# Altium schematic sheet 16-ESP32S3.SchDoc(16-ESP32S3)
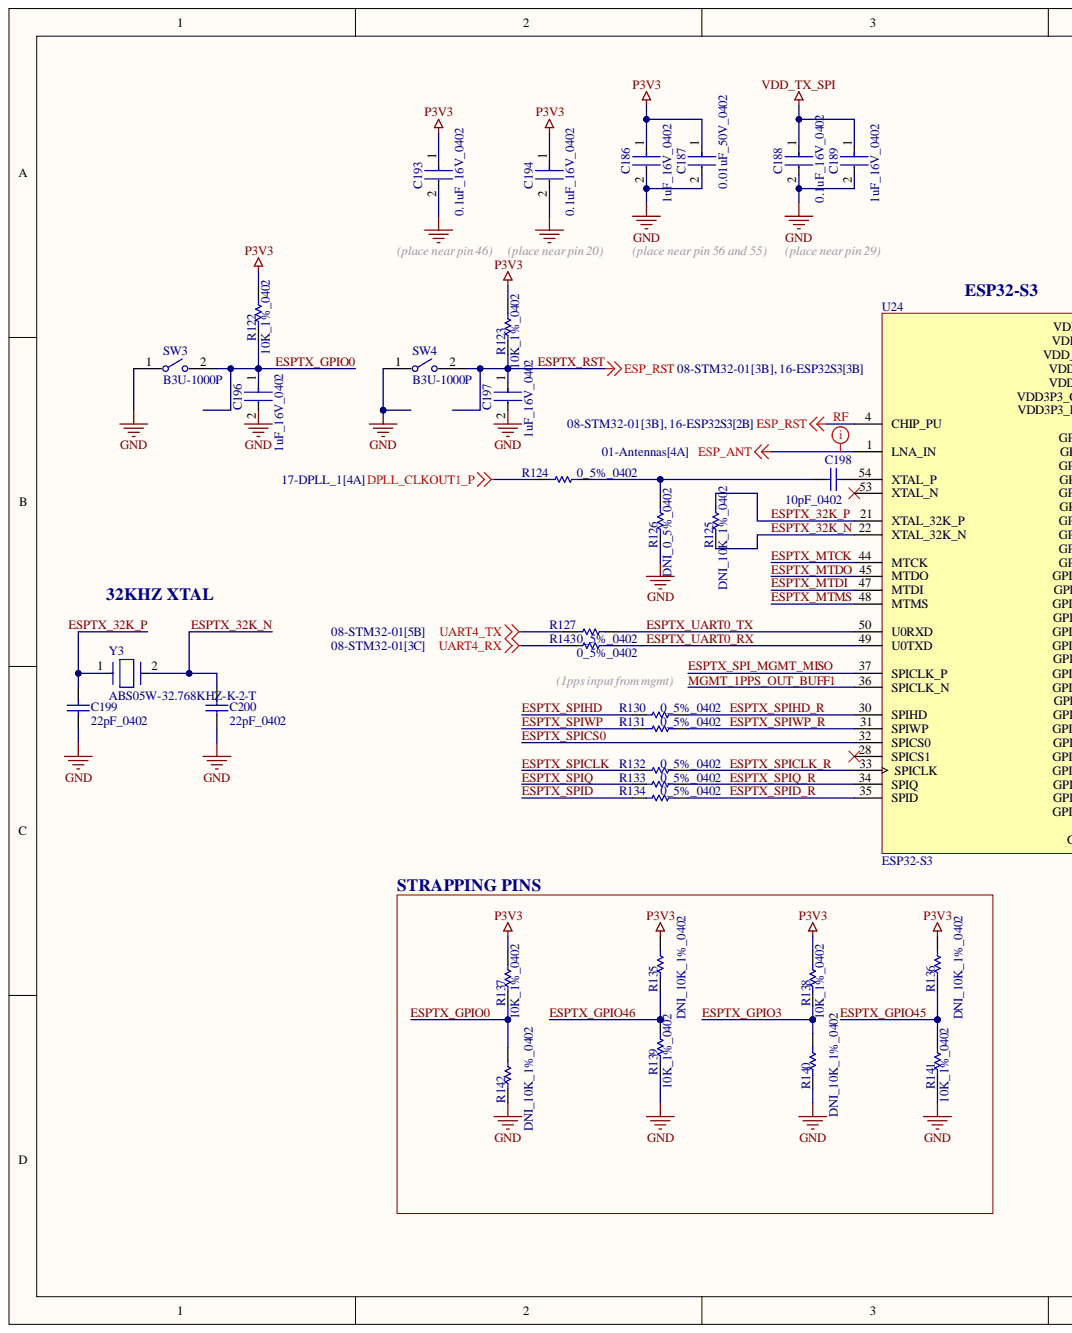
[diagram p0: 16-ESP32S3.SchDoc, left]
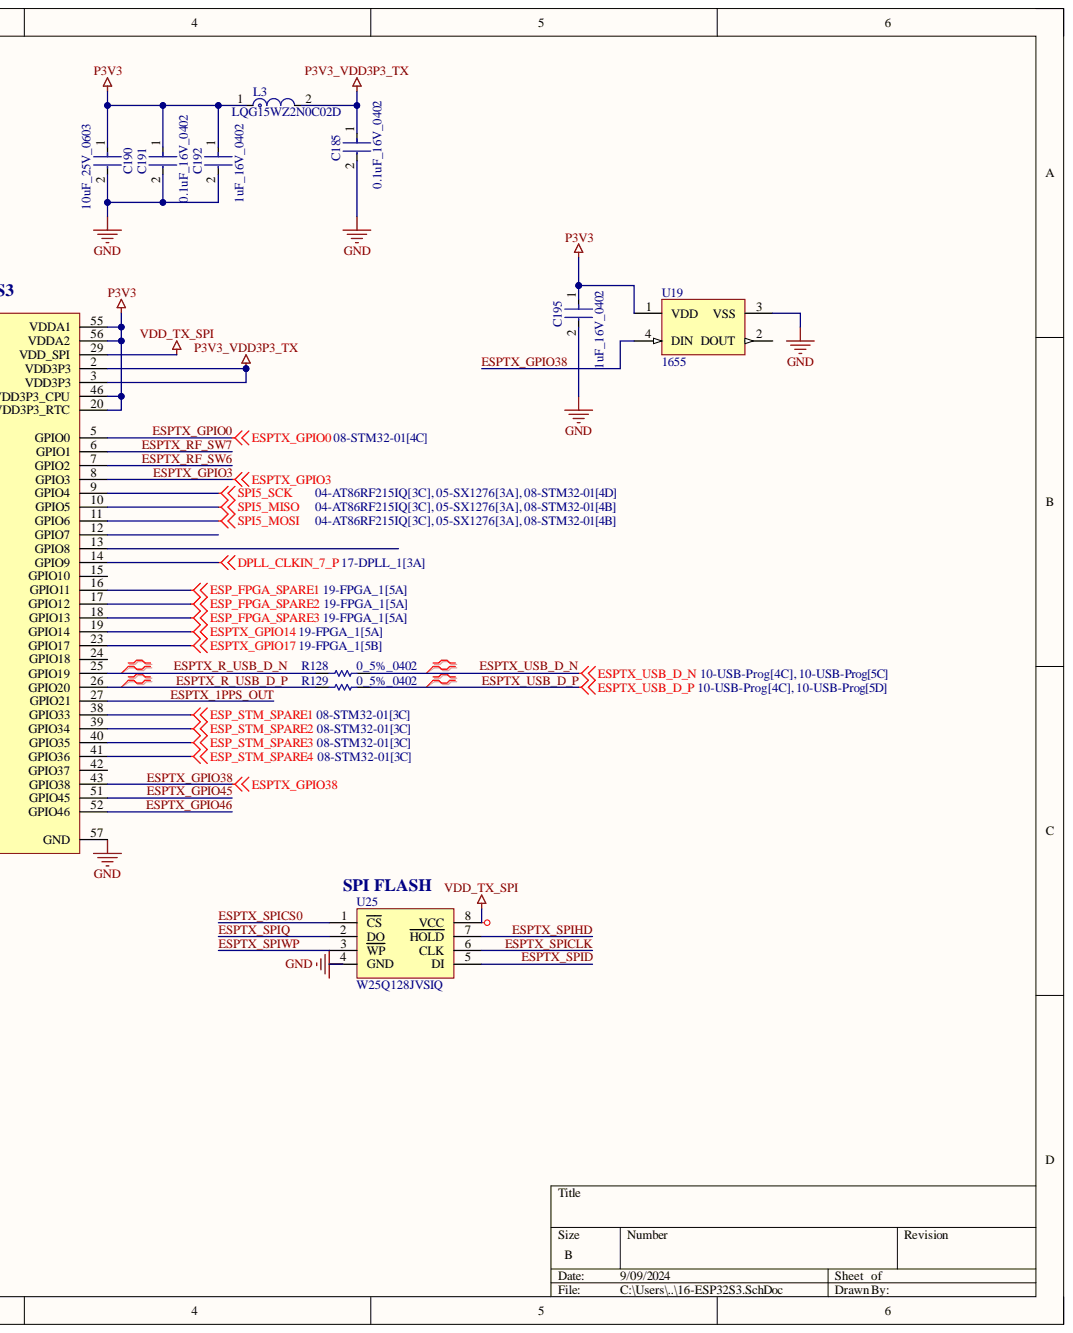
[diagram p1: 16-ESP32S3.SchDoc, right]

## Parts
C185  0.1uF_16V_0402  [FP-C0402-BB-MFG]
C186  1uF_16V_0402  [FP-0402-L_1_0_0_05-W_0_5-IPC_A]
C187  0.01uF_50V_0402  [FP-GCM155-0_05-IPC_C]
C188  0.1uF_16V_0402  [FP-C0402-BB-MFG]
C189  1uF_16V_0402  [FP-0402-L_1_0_0_05-W_0_5-IPC_A]
C190  10uF_25V_0603  [FP-CL10-IPC_C]
C191  0.1uF_16V_0402  [FP-C0402-BB-MFG]
C192  1uF_16V_0402  [FP-0402-L_1_0_0_05-W_0_5-IPC_A]
C193  0.1uF_16V_0402  [FP-C0402-BB-MFG]
C194  0.1uF_16V_0402  [FP-C0402-BB-MFG]
C195  1uF_16V_0402  [FP-0402-L_1_0_0_05-W_0_5-IPC_A]
C196  1uF_16V_0402  [FP-0402-L_1_0_0_05-W_0_5-IPC_A]
C197  1uF_16V_0402  [FP-0402-L_1_0_0_05-W_0_5-IPC_A]
C198  10pF_0402  [CAPC1005X55X25ML05T05]
C199  22pF_0402  [CAPC1005X55X25ML05T05]
C200  22pF_0402  [CAPC1005X55X25ML05T05]
L3  LQG15WZ2N0C02D  [FP-LQG15WH_02-IPC_B]
R122  10K_1%_0402  [RESC1005X40X25NL10T10]
R123  10K_1%_0402  [RESC1005X40X25NL10T10]
R124  0_5%_0402  [RESC1005X40X25NL05T10]
R125  DNI_10K_1%_0402  [RESC1005X40X25NL10T10]  (no BOM)
R126  DNI_0_5%_0402  [RESC1005X40X25NL05T10]  (no BOM)
R127  0_5%_0402  [RESC1005X40X25NL05T10]
R128  0_5%_0402  [RESC1005X40X25NL05T10]
R129  0_5%_0402  [RESC1005X40X25NL05T10]
R130  0_5%_0402  [RESC1005X40X25NL05T10]
R131  0_5%_0402  [RESC1005X40X25NL05T10]
R132  0_5%_0402  [RESC1005X40X25NL05T10]
R133  0_5%_0402  [RESC1005X40X25NL05T10]
R134  0_5%_0402  [RESC1005X40X25NL05T10]
R135  DNI_10K_1%_0402  [RESC1005X40X25NL10T10]  (no BOM)
R136  DNI_10K_1%_0402  [RESC1005X40X25NL10T10]  (no BOM)
R137  10K_1%_0402  [RESC1005X40X25NL10T10]
R138  10K_1%_0402  [RESC1005X40X25NL10T10]
R139  10K_1%_0402  [RESC1005X40X25NL10T10]
R140  DNI_10K_1%_0402  [RESC1005X40X25NL10T10]  (no BOM)
R141  10K_1%_0402  [RESC1005X40X25NL10T10]
R142  DNI_10K_1%_0402  [RESC1005X40X25NL10T10]  (no BOM)
R143  0_5%_0402  [RESC1005X40X25NL05T10]
SW3  B3U-1000P  [FP-B3U-1000P-MFG]
SW4  B3U-1000P  [FP-B3U-1000P-MFG]
U19  1655  [ADA-RGB-1655_V]
U24  ESP32-S3  [IC_ESP32-S3]
U25  W25Q128JVSIQ  [IC_W25Q128JVSIQ]
Y3  ABS05W-32.768KHZ-K-2-T  [FP-ABS05W-MFG]

## Nets
DPLL_CLKIN_7_P: U24.14(GPIO9)
DPLL_CLKOUT1_P: R124.1
ESP_ANT: U24.1(LNA_IN)
ESP_FPGA_SPARE1: U24.16(GPIO11)
ESP_FPGA_SPARE2: U24.17(GPIO12)
ESP_FPGA_SPARE3: U24.18(GPIO13)
ESP_STM_SPARE1: U24.38(GPIO33)
ESP_STM_SPARE2: U24.39(GPIO34)
ESP_STM_SPARE3: U24.40(GPIO35)
ESP_STM_SPARE4: U24.41(GPIO36)
ESPTX_GPIO14: U24.19(GPIO14)
ESPTX_GPIO17: U24.23(GPIO17)
GND: C185.2 C186.2 C187.2 C188.2 C189.2 C190.2 C191.2 C192.2 C193.2 C194.2 C195.2 C196.2 C197.2 C199.1 C200.1 R126.1 R139.1 R140.1 R141.1 R142.1 SW3.1 SW4.1 U19.3(VSS) U24.57(GND) U25.4(GND)
P3V3: C186.1 C187.1 C190.1 C191.1 C192.1 C193.1 C194.1 C195.1 L3.1 R122.2 R123.2 R135.2 R136.2 R137.2 R138.2 U19.1(VDD) U24.20(VDD3P3_RTC) U24.46(VDD3P3_CPU) U24.55(VDDA1) U24.56(VDDA2)
P3V3_VDD3P3_TX: C185.1 L3.2 U24.2(VDD3P3) U24.3(VDD3P3)
SPI5_MISO: U24.10(GPIO5)
SPI5_MOSI: U24.11(GPIO6)
SPI5_SCK: U24.9(GPIO4)
UART4_RX: R143.1
UART4_TX: R127.1
VDD_TX_SPI: C188.1 C189.1 U24.29(VDD_SPI) U25.8(VCC)
ESPTX_1PPS_OUT: U24.27(GPIO21)
ESPTX_32K_N: C200.2 R125.1 U24.22(XTAL_32K_N) Y3.2
ESPTX_32K_P: C199.2 R125.2 U24.21(XTAL_32K_P) Y3.1
ESPTX_GPIO0: C196.1 R122.1 R137.1 R142.2 SW3.2 U24.5(GPIO0)
ESPTX_GPIO3: R138.1 R140.2 U24.8(GPIO3)
ESPTX_GPIO38: U19.4(DIN) U24.43(GPIO38)
ESPTX_GPIO45: R136.1 R141.2 U24.51(GPIO45)
ESPTX_GPIO46: R135.1 R139.2 U24.52(GPIO46)
ESPTX_MTCK: U24.44(MTCK)
ESPTX_MTDI: U24.47(MTDI)
ESPTX_MTDO: U24.45(MTDO)
ESPTX_MTMS: U24.48(MTMS)
ESPTX_R_USB_D_N: R128.1 U24.25(GPIO19)
ESPTX_R_USB_D_P: R129.1 U24.26(GPIO20)
ESPTX_RF_SW6: U24.7(GPIO2)
ESPTX_RF_SW7: U24.6(GPIO1)
ESPTX_RST: C197.1 R123.1 SW4.2 U24.4(CHIP_PU)
ESPTX_SPI_MGMT_MISO: U24.37(SPICLK_P)
ESPTX_SPICLK: R132.1 U25.6(CLK)
ESPTX_SPICLK_R: R132.2 U24.33(SPICLK)
ESPTX_SPICS0: U24.32(SPICS0) U25.1(CS)
ESPTX_SPID: R134.1 U25.5(DI)
ESPTX_SPID_R: R134.2 U24.35(SPID)
ESPTX_SPIHD: R130.1 U25.7(HOLD)
ESPTX_SPIHD_R: R130.2 U24.30(SPIHD)
ESPTX_SPIQ: R133.1 U25.2(DO)
ESPTX_SPIQ_R: R133.2 U24.34(SPIQ)
ESPTX_SPIWP: R131.1 U25.3(WP)
ESPTX_SPIWP_R: R131.2 U24.31(SPIWP)
ESPTX_UART0_RX: R143.2 U24.49(U0TXD)
ESPTX_UART0_TX: R127.2 U24.50(U0RXD)
ESPTX_USB_D_N: R128.2
ESPTX_USB_D_P: R129.2
MGMT_1PPS_OUT_BUFF1: U24.36(SPICLK_N)
NetC198_1: C198.1 R124.2 R126.2
NetC198_2: C198.2 U24.54(XTAL_P)

Not connected: U19 2(DOUT); U24 12(GPIO7) 13(GPIO8) 15(GPIO10) 24(GPIO18) 28(SPICS1) 42(GPIO37) 53(XTAL_N)

## Library data of the parts
C185 C188 C191 C193 C194 = CMP-1034-02068-3: CAP CER 0.1UF 16V 5% X7R 0402; Manufacturer: Kemet; Manufacturer Part Number: C0402C104J4RACTU; Capacitance: 0.10 μF; Material: Ceramic; Temperature Coefficient: 15%; Tolerance: 0.05; Value: 0.10 μF; Automotive: No; Category: Cap; Component Kind: Standard; ComponentLink2Description: Datasheet; ComponentLink2URL: https://content.kemet.com/datasheets/KEM_C1002_X7R_SMD.pdf; Device Class L1: Passive Components; Device Class L2: Capacitors; Device Class L3: Ceramic Capacitors; Digikey Description: CAP CER 0.1UF 16V 5% X7R 0402; Height: 0.55mm; Ipc Land Pattern Name: CAPC10050X50; Lead Free: Yes; Library Name: Altium Content Vault; Mouser Description: CAP CER 0.1UF 16V X7R 0402; Octopart: https://octopart.com/c0402c104j4ractu-kemet-12573893; Package: C0402-BB; RoHS: TRUE; Temperature: -55°C to +125°C; Temperature Characteristic: X7R; Temperature Range High: +125°C; Temperature Range Low: -55°C; Voltage Rating: 16 V
C186 C189 C192 C195-C197 = CMP-14477-000104-2: None; Capacitance: 1uF; Dielectric: X5R; Tolerance: 10%; Voltage Rating: 16V; Case Code (Imperial): 0402; Case Code (Metric): 1005; Case/Package: 0402; Component Kind: Standard; Construction: Flat; Depth: 0.5mm; Halogen Free: Halogen Free; Height: 0.5mm; Length: 1mm; Library Name: Altium Content Vault; Max Operating Temperature: 85°C; Min Operating Temperature: -55°C; Mount: Surface Mount; Number of Pins: 2; Package Quantity: 1; Packaging: Tape and Reel; Radiation Hardening: No; RoHS Compliant: Yes; Series: M; Termination: SMD/SMT; Thickness: 0.022inch; Voltage Rating (DC): 16V; Width: 0.02inch
C187 = CMP-2008-03723-3: Ceramic Capacitor for Automotive 10nF ±10% 50VDC X7R 0402 Paper T/R; Voltage: 50V; Capacitance: 10nF; Case Code (Imperial): 0402; Case Code (Metric): 1005; Case/Package: 0402; Component Kind: Standard; Depth: 0.5mm; Dielectric: X7R; Height: 0.5mm; Lead Free: Lead Free; Length: 1mm; Library Name: Altium Content Vault; Max Operating Temperature: 125°C; Min Operating Temperature: -55°C; Mount: Surface Mount; Package Quantity: 1; Packaging: Tape and Reel; Radiation Hardening: No; Ratings: AEC-Q200; REACH SVHC: No SVHC; Termination: SMD/SMT; Thickness: 0.022inch; Tolerance: 10%; Voltage Rating: 50V; Voltage Rating (DC): 50V; Width: 0.02inch
C190 = CMP-13271-004224-1: Cap Ceramic 10uF 25V X5R ±20% Pad SMD 0603 +85°C T/R; Capacitance: 10uF; Case Code (Imperial): 0603; Case Code (Metric): 1608; Case/Package: 0603; Component Kind: Standard; Contact Plating: Tin; Dielectric: X5R; Lead Free: Lead Free; Length: 0.063inch; Library Name: Altium Content Vault; Max Operating Temperature: 85°C; Min Operating Temperature: -55°C; Mount: Surface Mount; Package Quantity: 1; Packaging: Tape and Reel; Termination: Wraparound; Thickness: 0.035inch; Tolerance: 20%; Voltage Rating: 25V; Width: 0.031inch
C198 = CMP-2100-03589-1: Chip Capacitor, 10 pF, +/- 5%, 50 V, -55 to 125 degC, 0402 (1005 Metric), RoHS, Tape and Reel; Manufacturer: Yageo; Capacitance: 10pF; Dielectric: C0G; Dielectric Material: Ceramic; Insulation Resistance: 100GR; Temperature Coefficient: 30ppm/degC; Voltage: 50V; Case Code (Imperial): 0402; Case Code (Metric): 1005; Case/Package: 0402; Component Kind: Standard; Composition: Ceramic; Datasheet URL: http://www.yageo.com/documents/recent/UPY-GP_NP0_16V-to-50V_14.pdf; Datasheet Version: Rev. 14, 07/2016; Depth: 0.5mm; Height: 0.5mm; Lead Free: LeadFree; Length: 1mm; Library Name: Altium Content Vault; Manufacturer URL: http://www.yageo.com/; Max Operating Temperature: 125degC; Min Operating Temperature: -55degC; Mount: SurfaceMount; Number of Pins: 2; Package Description: 2-Pin Surface Mount Device, Body 1 x 0.5 mm; Package Quantity: 1; Package Reference: 0402; Packaging: TapeandReel; Radiation Hardening: No; REACH SVHC: NoSVHC; RoHSCompliant: True; Termination: SMD/SMT; Thickness: 0.022inch; Tolerance: 5%; Voltage Rating: 50V; Voltage Rating (DC): 50V; Width: 0.02inch
C199 C200 = CMP-2100-03590-1: Chip Capacitor, 22 pF, +/- 5%, 50 V, -55 to 125 degC, 0402 (1005 Metric), RoHS, Tape and Reel; Manufacturer: Yageo; Capacitance: 22pF; Dielectric: C0G; Dielectric Material: Ceramic; Insulation Resistance: 100GR; Temperature Coefficient: 30ppm/degC; Voltage Rating: 50V; Case Code (Imperial): 0402; Case Code (Metric): 1005; Case/Package: 0402; Component Kind: Standard; Composition: Ceramic; Datasheet URL: http://www.yageo.com/NewPortal/yageodocoutput?fileName=/pdf/MLCC/UPY-GP_NP0_16V-to-50V_14.pdf; Datasheet Version: Rev. 14, 07/2016; Depth: 0.5mm; Height: 0.5mm; Lead Free: LeadFree; Length: 1mm; Library Name: Altium Content Vault; Manufacturer URL: http://www.yageo.com/; Max Operating Temperature: 125degC; Min Operating Temperature: -55degC; Mount: SurfaceMount; Number of Pins: 2; Package Description: 2-Pin Surface Mount Device, Body 1 x 0.5 mm; Package Quantity: 1; Package Reference: 0402; Packaging: TapeandReel; Radiation Hardening: No; REACH SVHC: NoSVHC; RoHSCompliant: True; Termination: SMD/SMT; Thickness: 0.022inch; Tolerance: 5%; Voltage Rating (DC): 50V; Width: 0.02inch
L3 = CMP-06042-012509-1: Multilayer type Inductor for Automotive 2nH ±0.2nH 0.05Ω 1000mA 0402; Core Material: Air; Current Rating: 1A; DC Resistance (DCR): 50mR; Self Resonant Frequency: 8GHz; Shielding: Unshielded; Test Frequency: 100MHz; Case Code (Imperial): 0402; Case Code (Metric): 1005; Case/Package: 0402; Component Kind: Standard; Inductance: 2nH; Library Name: Altium Content Vault; Max Operating Temperature: 125°C; Min Operating Temperature: -55°C; Mount: Surface Mount; Packaging: Cut Tape; Ratings: AEC-Q200
R122 R123 R125 R135-R142 = CMP-2002-04694-1: Manufacturer: Bourns; Part Number: CR0402-FX-1002GLF; Library Name: Altium Content Vault
  R122 R123 R137-R139 R141: Component Kind: Standard
R124 R126-R134 R143 = CMP-2002-06457-1: Manufacturer: Panasonic; Part Number: ERJ-U020R00X; Library Name: Altium Content Vault
  R124 R127-R134 R143: Component Kind: Standard
SW3 SW4 = CMP-2100-03586-2: SWITCH TACTILE SPST-NO 0.05A 12V; Actuator Length: 0.4mm; Actuator Type: Standard; Contact Current Rating: 50mA; Contact Resistance: 100mR; Electrical Life: 200000Cycles; Illumination Type: Non-Illuminated; Operating Force: 1.5N; Ratings: Dust Proof; Switch Function: Off-Mom; Switch Travel: 0.15mm; Throw Configuration: SPST; Component Kind: Standard; Contact Material: Silver; Current Rating: 50mA; Depth: 2.5mm; Height: 1.2mm; Insulation Resistance: 100MR; Lead Free: Lead Free; Length: 4mm; Library Name: Altium Content Vault; Max Current Rating: 50mA; Max Operating Temperature: 70°C; Max Voltage Rating (DC): 12V; Mechanical Life: 200000Cycles; Min Operating Temperature: -25°C; Mount: Surface Mount; Orientation: Straight; Package Quantity: 1; Packaging: Tape and Reel; Termination: SMD/SMT; Voltage Rating (DC): 12V; Weight: 0.000776oz; Width: 3mm
U19 = CMP-2000-05026-1: Intelligent Control LED Integrated Light Source, 3.5 to 5.3 V, -25 to 80 degC, 4-Pin SMD, RoHS, Tape and Reel; Manufacturer: Adafruit-Industries-LLC; Configuration: Discrete; Number of LEDs: 10; Utilized LED: WS2812(5050); Category: Optoelectronics; Color: Red,Green,Blue(RGB); Component Kind: Standard; Controller Type: -; Datasheet URL: http://datasheet.octopart.com/ADA1655-Adafruit-Industries-datasheet-32028139.pdf; Datasheet Version: May-13; Family: Addressable,Specialty; Interface: -; Library Name: Altium Content Vault; Manufacturer URL: https://www.adafruit.com/; Mounting Type: SurfaceMount; Package Description: 4-Pin Surface Mount Device, Body 5 x 5 mm; Package Reference: 1655; Series: Neopixel; Size / Dimension: 5.00mmLx5.00mmW
U24 = IC_ESP32-S3: IC RF TxRx + MCU Bluetooth, WiFi 802.11b/g/n, Bluetooth v5.0 2.412GHz ~ 2.484GHz 56-VFQFN Exposed Pad; MF: Espressif Systems; Availability: In Stock; Check_prices: https://www.snapeda.com/parts/ESP32-S3/Espressif+Systems/view-part/?ref=eda; Component Kind: Standard; MP: ESP32-S3; Package: None; Price: None; Purchase-URL: https://pricing.snapeda.com/search/part/ESP32-S3/?ref=eda; SnapEDA_Link: https://www.snapeda.com/parts/ESP32-S3/Espressif+Systems/view-part/?ref=snap; Supplier 1: LCSC; Supplier Part Number 1: C2913192; Library Name: LotusSound.SchLib
U25 = IC_W25Q128JVSIQ: NOR Flash Serial (SPI, Dual SPI, Quad SPI) 3.3V 128M-bit 16M x 8 6ns 8-Pin SOIC Tube; Manufacturer: Winbond; Frequency: 133MHz; Memory Format: Non-Volatile,FLASH; Memory Size: 128Mb; Case/Package: SOIC; Category: Flash; Component Kind: Standard; ComponentLink1Description: http://www.winbond.com/resource-files/w25q128jv%20revf%2003272018%20plus.pdf?src-supplier=Digi-Key; ComponentLink1URL: http://www.winbond.com/resource-files/w25q128jv%20revf%2003272018%20plus.pdf?src-supplier=Digi-Key; Manufacturer Part Number: W25Q128JVSIQ; Max Operating Temperature: 85°C; Min Operating Temperature: -40°C; Mounting Technology: Surface Mount; Packaging: Tray; Pricing 1: 1=1.71, 10=1.562, 25=1.52, 50=1.5124, 100=1.3519, 250=1.348, 500=1.32762, 1000=1.27127, 5000=1.18359 (USD); RoHS: Yes; RoHS Compliant: Yes; Series: SpiFlash®; Stock 1: 154352; Supplier 1: Digi-Key; Supplier Part Number 1: W25Q128JVSIQ-ND; Library Name: LotusSound.IntLib
Y3 = CMP-27762-015607-1: Crystal 32.768kHz ±20ppm (Tol) 4pF 85kΩ 2-Pin SMD T/R; ESR (Equivalent Series Resistance): 85kR; Case/Package: SMD/SMT; Component Kind: Standard; Frequency: 32.768kHz; Frequency Tolerance: 20ppm; Library Name: Altium Content Vault; Load Capacitance: 4pF; Max Operating Temperature: 125°C; Min Operating Temperature: -40°C; Mount: Surface Mount; Packaging: Cut Tape; Series: ABS05W
